# Altium schematic sheet POE.SchDoc
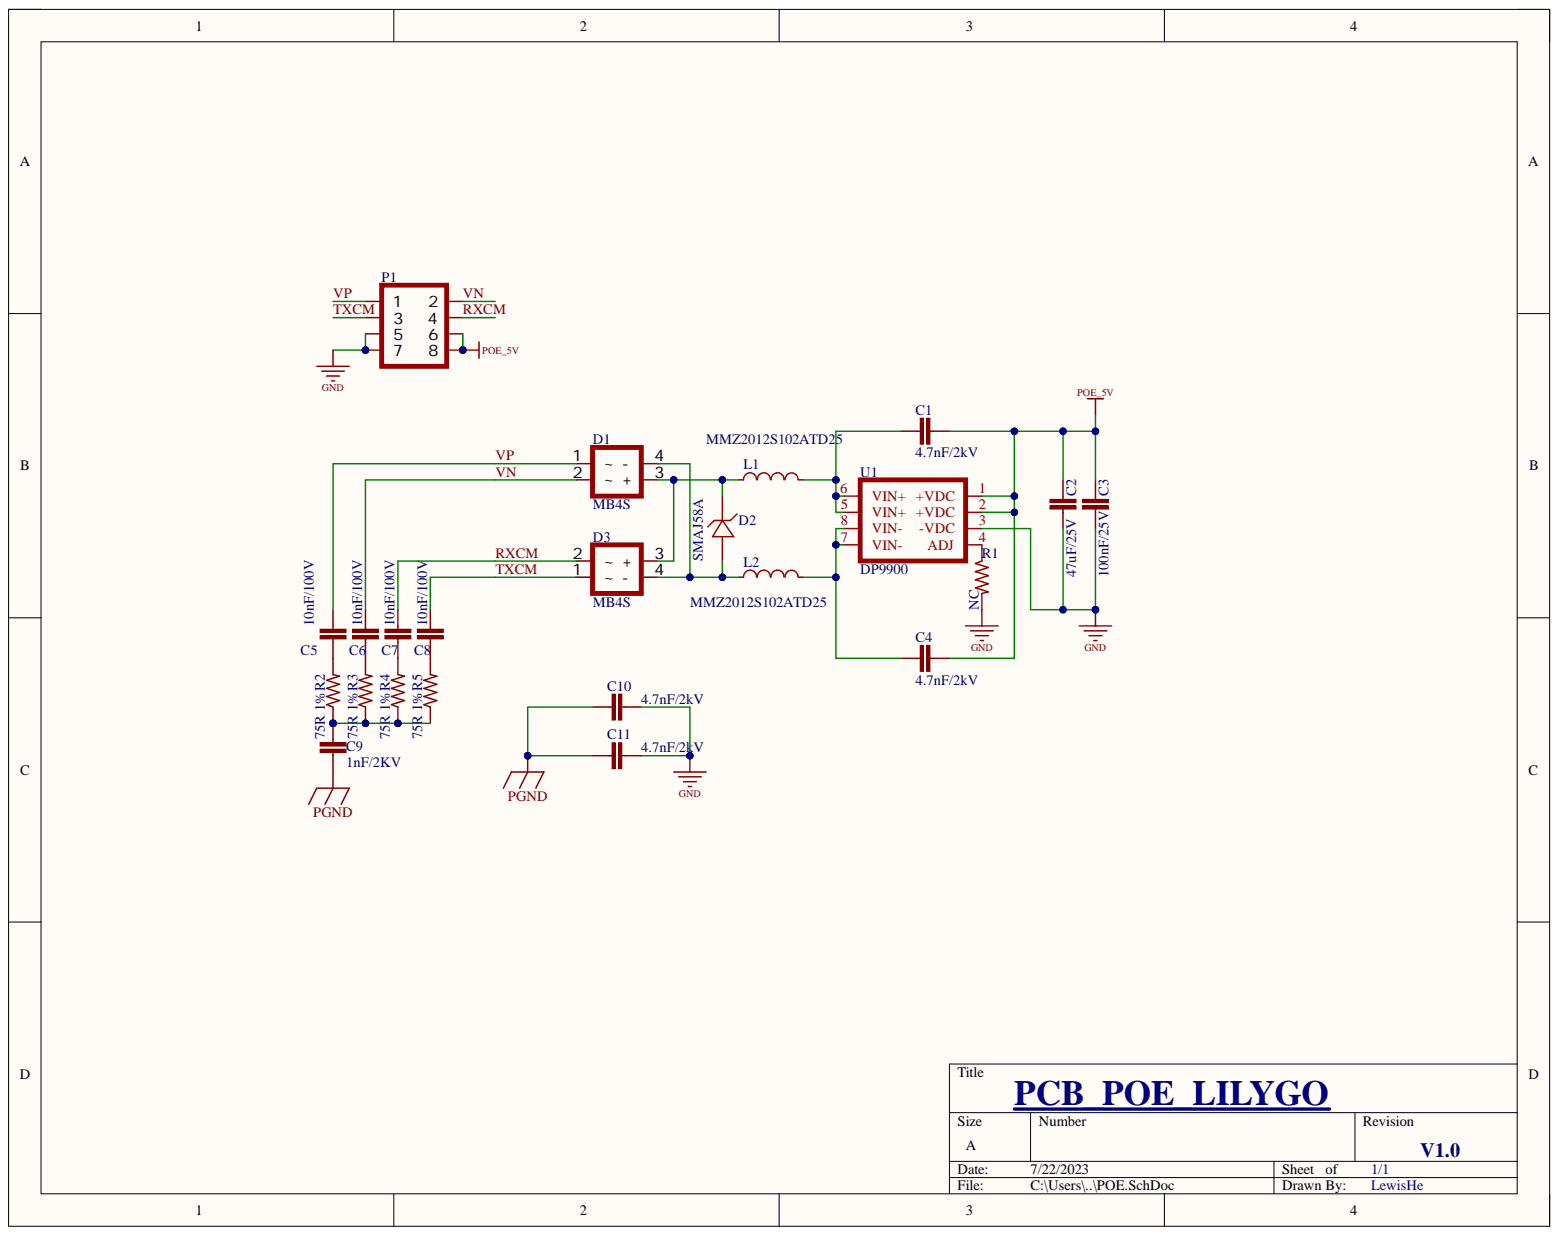
[diagram p0: POE.SchDoc]

## Parts
C1  4.7nF/2kV  [C1206]
C2  47uF/25V  [C0805]
C3  100nF/25V  [C0805]
C4  4.7nF/2kV  [C1206]
C5  10nF/100V  [C0603]
C6  10nF/100V  [C0603]
C7  10nF/100V  [C0603]
C8  10nF/100V  [C0603]
C9  1nF/2KV  [C1206]
C10  4.7nF/2kV  [C1206]
C11  4.7nF/2kV  [C1206]
D1  MB4S  [DIO-BG-SMD_MBS]
D2  SMAJ58A  [SMA_L4.3-W2.6-LS5.2-RD]
D3  MB4S  [DIO-BG-SMD_MBS]
L1  MMZ2012S102ATD25  [L0805]
L2  MMZ2012S102ATD25  [L0805]
P1  Header2x4  [HDR-TH_8P-P2.54-V-F-R2-C4-S2.54-1]  (value not drawn)
R1  NC  [R0603]
R2  75R 1%  [R0603]
R3  75R 1%  [R0603]
R4  75R 1%  [R0603]
R5  75R 1%  [R0603]
U1  DP9900  [DP9900]

## Nets
GND: C2.1 C3.1 C10.1 C11.1 P1.5 P1.7 R1.2 U1.3(-VDC)
PGND: C9.1 C10.2 C11.2
POE_5V: C1.1 C2.2 C3.2 C4.1 P1.6 P1.8 U1.1(+VDC) U1.2(+VDC)
RXCM: C7.2 D3.2(~) P1.4
TXCM: C8.2 D3.1(~) P1.3
VN: C6.2 D1.2(~) P1.2
VP: C5.2 D1.1(~) P1.1
NetC1_2: C1.2 L1.2 U1.5(VIN+) U1.6(VIN+)
NetC4_2: C4.2 L2.2 U1.7(VIN-) U1.8(VIN-)
NetC5_1: C5.1 R2.2
NetC6_1: C6.1 R3.2
NetC7_1: C7.1 R4.2
NetC8_1: C8.1 R5.2
NetC9_2: C9.2 R2.1 R3.1 R4.1 R5.1
NetD1_3: D1.3(+) D2.1 D3.3(+) L1.1
NetD1_4: D1.4(-) D2.2 D3.4(-) L2.1
NetR1_1: R1.1 U1.4(ADJ)

## Library data of the parts
C1-C11 = C0201: 0201  贴片电容; Component Kind: Standard; Library Name: 常用.SCHLIB
D1 D3 = MB4S: 直流反向耐压(Vr):400V;平均整流电流(Io):800mA;正向压降(Vf):1V@400mA;反向电流(Ir):5uA@400V;正向浪涌电流(Ifsm):35A;工作温度:-55℃~+150℃@(Tj);; Component Kind: Standard; ComponentLink1Description: 立创链接; ComponentLink1URL: https://item.szlcsc.com/267183.html; Library Name: 常用.SCHLIB
D2 = SMAJ58A: 极性:单向;反向截止电压(Vrwm):58V;击穿电压:64.4V;峰值脉冲电流(Ipp)@10/1000s:-;最大钳位电压:93.6V;峰值脉冲功率(Ppp)@10/1000s:400W;; Component Kind: Standard; ComponentLink1Description: 立创链接; ComponentLink1URL: https://item.szlcsc.com/163270.html; Library Name: 常用.SCHLIB
L1 L2 = MMZ2012S102ATD25: 标称阻抗@测试频率:1kΩ@100MHz;误差:±25%;直流电阻(RDC):350mΩ;额定电流:500mA;电路数:1;; Component Kind: Standard; ComponentLink1Description: 立创链接; ComponentLink1URL: https://item.szlcsc.com/514495.html; Library Name: 常用.SCHLIB
R1-R5 = R0201: Component Kind: Standard; Library Name: 常用.SCHLIB
P1 = Header2x4: Component Kind: Standard; Description: Header2x4; Library Name: 常用.SCHLIB
U1 = DP9900: Component Kind: Standard; Library Name: 常用.SCHLIB
